# Altium schematic sheet power switch board.SchDoc
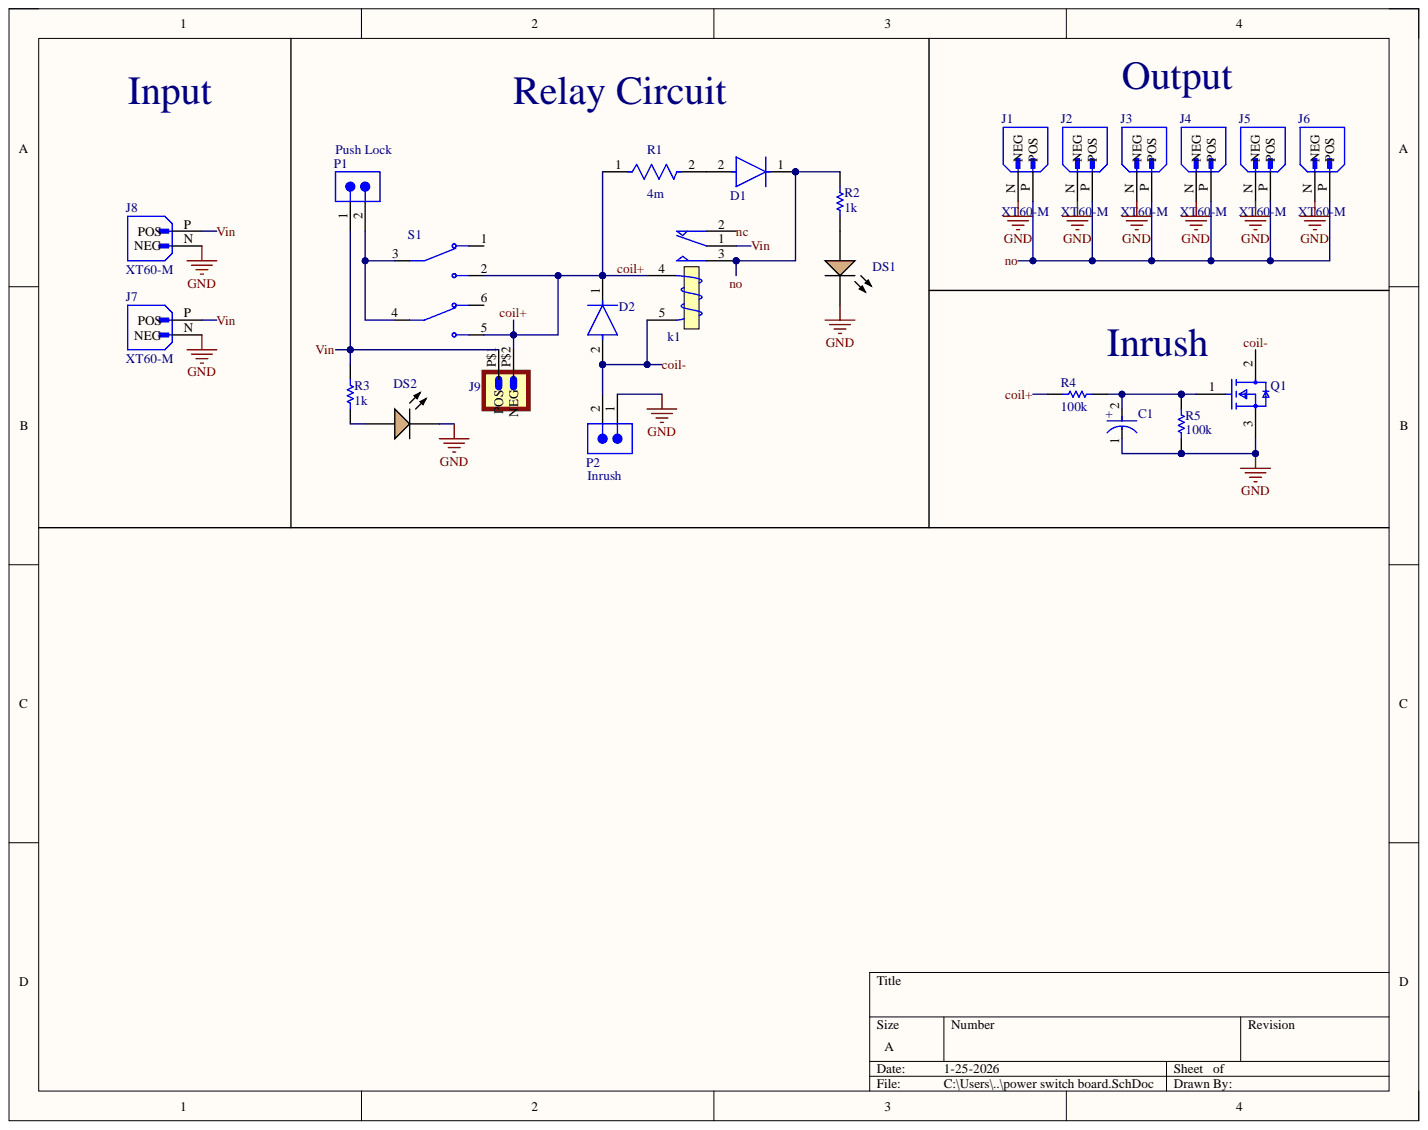
[diagram p0: power switch board.SchDoc]

## Parts
C1  UPW1E220MDD  [FP-UPW1E220MDD-MFG]  (value not drawn)
D1  BYM10-1000-E3/97  [FP-GL41-MFG]  (value not drawn)
D2  BAV103-GS18  [FP-6_560-5005_01-4-MFG]  (value not drawn)
DS1  HSMA-C150  [AVAG-HSMX-C150_V]  (value not drawn)
DS2  HSMA-C150  [AVAG-HSMX-C150_V]  (value not drawn)
J1  XT60-M  [AMASS_XT60-M]
J2  XT60-M  [AMASS_XT60-M]
J3  XT60-M  [AMASS_XT60-M]
J4  XT60-M  [AMASS_XT60-M]
J5  XT60-M  [AMASS_XT60-M]
J6  XT60-M  [AMASS_XT60-M]
J7  XT60-M  [AMASS_XT60-M]
J8  XT60-M  [AMASS_XT60-M]
J9  XT30UPB-M  [XT30UPB-M]  (value not drawn)
k1  Relay 5pin T90 12V  [REL_30A_T90]  (value not drawn)
P1  Push Lock  [61300211121]
P2  Inrush  [61300211121]
Q1  IPP110N20N3GXKSA1  [FP-PG-TO220-3-MFG]  (value not drawn)
R1  4m  [FP-MCS3264-MFG]
R2  1k  [RESC2013X60X35ML10T20]
R3  1k  [RESC2013X60X35ML10T20]
R4  100k  [RESC2013X60X35ML10T20]
R5  100k  [RESC2013X60X35ML10T20]
S1  TL2285OA  [SW_TL2285OA]  (value not drawn)

## Nets
GND: C1.1 DS1.2 DS2.2 J1.N J2.N J3.N J4.N J5.N J6.N J7.N J8.N P2.1 Q1.3 R5.2
coil+: D2.1 J9.P$2 k1.4 R1.1 R4.1 S1.2 S1.5
coil-: D2.2 k1.5 P2.2 Q1.2
nc: k1.2
no: D1.1 J1.P J2.P J3.P J4.P J5.P J6.P k1.3 R2.1
Vin: J7.P J8.P J9.P$1 k1.1 P1.1 R3.1
NetC1_2: C1.2 Q1.1 R4.2 R5.1
NetD1_2: D1.2 R1.2
NetDS1_1: DS1.1 R2.2
NetDS2_1: DS2.1 R3.2
NetP1_2: P1.2 S1.3 S1.4

Not connected: S1 1 6

## Library data of the parts
C1 = CMP-12462-000010-1: CAP ALUM 22UF 20% 25V RADIAL; Manufacturer: Nichicon; Automotive Grade: Grade 2; Dissipation Factor: 14%; Leakage Current: 16.5uA; Life: 2000Hours; Material: Aluminum; Ripple Current: 180mA; Automotive: Yes; Capacitance: 22uF; Category: Cap; Component Kind: Standard; ComponentLink2Description: Datasheet; ComponentLink2URL: https://products.nichicon.co.jp/en/pdf/XJA043/e-upw.pdf; Device Class L1: Passive Components; Device Class L2: Capacitors; Device Class L3: Aluminum Electrolytic Capacitors; Digikey Description: CAP ALUM 22UF 20% 25V RADIAL; Height: 12.5mm; Lead Free: Yes; Library Name: Altium Content Vault; Manufacturer Part Number: UPW1E220MDD; Octopart: https://octopart.com/upw1e220mdd-nichicon-202818; Package: 0; RoHS: TRUE; Temperature: -55°C to +105°C; Temperature Range High: +105°C; Temperature Range Low: -55°C; Tolerance: 20%; Value: 22uF; Voltage Rating: 25V
D1 = CMP-02540-000108-1: DIODE GEN PURP 1KV 1A DO213AB; Element Configuration: Single; Forward Voltage: 1.2V; Max Forward Surge Current (Ifsm): 30A; Max Reverse Current: 10uA; Average Rectified Current: 1A; Case/Package: DO-213AB; Component Kind: Standard; Contact Plating: Tin; Forward Current: 1A; Height: 2.67mm; Lead Free: Lead Free; Length: 5.2mm; Library Name: Altium Content Vault; Max Operating Temperature: 175°C; Max Repetitive Reverse Voltage (Vrrm): 1kV; Max Reverse Voltage (DC): 1kV; Max Surge Current: 30A; Min Operating Temperature: -65°C; Mount: Surface Mount; Number of Pins: 2; Package Quantity: 5000; Packaging: Tape and Reel; Peak Non-Repetitive Surge Current: 30A; Radiation Hardening: No; Reverse Voltage: 1kV; RoHS Compliant: Yes; Series: SUPERECTIFIER®; Width: 2.67mm
D2 = CMP-02540-000035-1: DIODE GEN PURP 200V 250MA SOD80; Manufacturer: Vishay Semiconductor Diodes Division; Automotive Grade: Grade 0; Capacitance: 1.5pF; Max Junction Temp: +175°C; Max Repetitive Reverse Voltage: 250V; Max Surge Forward Current: 1A; Peak Reverse Current: 0.1uA; Value: 1.5pF; Automotive: Yes; Category: Diode; Component Kind: Standard; ComponentLink2Description: Datasheet; ComponentLink2URL: http://www.vishay.com/docs/85542/bav100.pdf; Device Class L1: Discrete Semiconductors; Device Class L2: Diodes; Device Class L3: Rectifier Diodes; Digikey Description: DIODE GEN PURP 200V 250MA SOD80; Forward Current: 250mA; Height: 1.6mm; Ipc Land Pattern Name: DIOMELF350150; Lead Free: Yes; Library Name: Altium Content Vault; Manufacturer Part Number: BAV103-GS18; Mouser Description: Diode Small Signal Switching 250V 0.25A Automotive 2-Pin Mini-MELF SOD-80 T/R; Octopart: https://octopart.com/bav103-gs18-vishay-55507560; Package: 6.560-5005.01-4; Reverse Voltage: 200V; RoHS: TRUE; Temperature: to +175°C; Temperature Range High: +175°C
DS1 DS2 = CMP-2000-06420-1: High Performance Chip LED, 60 mW, 25 mA, -30 to 85 degC, 2-Pin SMD, RoHS, Tape and Reel; Brand: AvagoTechnologies; Forward Current: 20mA; Forward Voltage: 1.9V; Illumination Color: Amber; LED Size: 3.2mmx1.6mmx1.1mm; Lens Color/Style: Diffused; Luminous Intensity: 90mcd; Power Rating: 60mW; Viewing Angle: 170deg; Wavelength/Color Temperature: 592nm; Component Kind: Standard; Datasheet URL: https://datasheet.ciiva.com/29280/180503-da-01-en-chip-led-hsma-c150-gelb-29280992.pdf; Datasheet Version: 03/2008; Factory Pack Quantity: 3000; Library Name: Altium Content Vault; Manufacturer: AvagoTechnologies; Manufacturer URL: http://www.avagotech.com/; Maximum Operating Temperature: +85C; Minimum Operating Temperature: -40C; Mounting Technology: SurfaceMount; Package / Case: 3.2mmx1.6mmx1.1mm; Package Description: 2-Pin Surface Mount Device, Body 3.2 x 1.6 mm; Package Reference: HSMX-C150; Packaging: Reel; Product Category: StandardLEDs-SMD; RoHS: Details
J1-J8 = XT60-M: Plug; DC supply; XT60; male; PIN: 2; for cable; soldered; 30A; 500V; MF: AMASS; Check_prices: https://www.snapeda.com/parts/XT60-M/AMASS/view-part/?ref=eda; Component Kind: Standard; Library Name: XT60-M.IntLib; MP: XT60-M; Package: Package; SnapEDA_Link: https://www.snapeda.com/parts/XT60-M/AMASS/view-part/?ref=snap
J9 = XT30UPB-M: Socket; DC supply; XT30; male; PIN: 2; on PCBs; THT; yellow; 15A; 500V; MF: amass; Availability: Not in stock; Check_prices: https://www.snapeda.com/parts/XT30UPB-M/AMASS/view-part/?ref=eda; Component Kind: Standard; Library Name: XT30UPB-M (1).IntLib; MP: XT30UPB-M; Package: None; Price: None; SnapEDA_Link: https://www.snapeda.com/parts/XT30UPB-M/AMASS/view-part/?ref=snap
k1 = Relay 5pin T90 12V: PartNumber: CMP7-S-DC12V-C; Component Kind: Standard; Library Name: Relay (2).IntLib
P1 P2 = CMP-1712-00002-6: NexarPartId: 20081794; Connector Type: Male Pin; Contact Resistance: 20mΩ; Current Rating: 3A; Gender: Male; Number of Contacts: 2; Operating Supply Voltage: 250V; Pitch: 2.54mm; Color: Black; Component Kind: Standard; Contact Gender: Male; Contact Material: Copper; Contact Mating Length: 5.9944mm; Contact Plating: Gold; Height: 8.94mm; Housing Material: PBT; Lead Free: Lead Free; Lead Pitch: 2.54mm; Library Name: Altium Content Vault; Max Operating Temperature: 125°C; Min Operating Temperature: -40°C; Mount: Through Hole; Number of Pins: 2; Number of Positions: 2; Number of Rows: 1; Orientation: Vertical; Packaging: Bulk; REACH SVHC: No SVHC; RoHS: Compliant; Termination: Through Hole; Voltage Rating: 250V
Q1 = CMP-32901-000032-1: MOSFET N-CH 200V 88A TO220-3; Manufacturer: Infineon; Depletion Mode: False; Drain To Source Resistance: 9.9mΩ; Gate Charge At Vgs: 65nC @ 10V; Gate To Source Voltage: 20V; Input Capacitace At Vds: 5340pF @ 100V; Max Forward Diode Voltage: 1.2V; Max Junction Temp: +175°C; Number Of Channels: 1; Power Dissipation: 300W; Pulse Drain Current: 352A; Reverse Recovery Charge: 640nC; Reverse Recovery Time: 142ns; Rthja Max: 62K/W; Standoff Height: 4.35mm; Threshold Vgs Max: 4V; Threshold Vgs Min: 2V; Transconductance: 141S; Turn Off Delay Time: 41ns; Turn On Delay Time: 18ns; Typ Forward Diode Voltage: 1V; Automotive: No; Category: Trans; Component Kind: Standard; ComponentLink2Description: Datasheet; ComponentLink2URL: https://www.infineon.com/dgdl/Infineon-IPP_I_110N20N3G_IPB107N20N3G-DS-v02_03-en.pdf?fileId=db3a3043243b5f170124968e7d1f18e7; Continuous Drain Current: 88A; Device Class L1: Discrete Semiconductors; Device Class L2: Transistors; Device Class L3: MOSFETs; Digikey Description: MOSFET N-CH 200V 88A TO220-3; Drain To Source Breakdown Voltage: 200V; Drain To Source Voltage: 200V; Footprint Url: https://www.infineon.com/cms/en/product/packages/PG-TO220/PG-TO220-3-1/; Height: 20.7mm; Lead Free: Yes; Library Name: Altium Content Vault; Manufacturer Part Number: IPP110N20N3GXKSA1; Mouser Description: MOSFET N-Ch 200V 88A TO220-3 OptiMOS 3; Number Of N Channels: 1; Octopart: https://octopart.com/ipp110n20n3gxksa1-infineon-21386929; Package: PG-TO220-3; RoHS: TRUE; Temperature: -55°C to +175°C; Temperature Range High: +175°C; Temperature Range Low: -55°C
R1 = CMP-33361-000012-1: RES SMD 10 MOHM 1% 2W 3264; Resistance: 10mR; Temperature Coefficient: 50ppm/°C; Voltage Rating: 220mV; Case Code (Imperial): 2512; Case Code (Metric): 6432; Case/Package: 2512; Component Kind: Standard; Composition: Metal Element; Depth: 3.2mm; Features: Flame Retardant Coating; Height: 0.024inch; Length: 6.4mm; Library Name: Altium Content Vault; Max Operating Temperature: 150°C; Max Power Dissipation: 2W; Military Standard: Not; Min Operating Temperature: -55°C; Mount: Surface Mount; Number of Pins: 2; Number of Terminations: 2; Package Quantity: 1; Packaging: Tape and Reel; Power Rating: 2W; Termination: SMD/SMT; Tolerance: 1%; Width: 0.126inch
R2-R5 = CMP-2100-03673-1: Chip Resistor, 0 Ohm, Jumper, +/- 5%, 0.125 W, -55 to 155 degC, 0805 (2012 Metric), RoHS, Tape and Reel; Manufacturer: Yageo; Power Rating: 125mW; Resistance: 0mOhm; Temperature Coefficient: 200ppm/degreesC; Voltage Rating: 150V; Case Code (Imperial): 0805; Case Code (Metric): 2012; Case/Package: 0805; Component Kind: Standard; Composition: ThickFilm; Contact Plating: Tin; Datasheet URL: http://www.yageo.com/NewPortal/yageodocoutput?fileName=/pdf/R-Chip/PYu-RC_Group_51_RoHS_L_5.pdf; Datasheet Version: Rev. 5, 10/2016; Depth: 1.25mm; Height: 0.5mm; Lead Free: LeadFree; Length: 2mm; Library Name: Altium Content Vault; Manufacturer URL: http://www.yageo.com/; Max Operating Temperature: 155degC; Military Standard: Not; Min Operating Temperature: -55degC; Number of Pins: 2; Package Description: 2-Pin Surface Mounted Device, Body 2 x 1.25 mm; Package Reference: 0805; Packaging: TapeandReel; Radiation Hardening: No; REACH SVHC: Unknown; RoHSCompliant: true; Termination: SMD/SMT; Tolerance: 5%; Voltage Rating (DC): 150V; Width: 1.25mm
S1 = TL2285OA: Pushbutton Switch, TL2285, DPDT, ON-(ON), Plunger for Cap, 100mA, 30VDC,PC Pin | E-Switch TL2285OA; Check_prices: https://www.snapeda.com/parts/TL2285OA/E-Switch/view-part/?ref=eda; Component Kind: Standard; Library Name: TL2285OA (1).IntLib; MF: E-Switch; MP: TL2285OA; Package: None; SnapEDA_Link: https://www.snapeda.com/parts/TL2285OA/E-Switch/view-part/?ref=snap
